# Altium schematic sheet PD2.SchDoc(PD2)
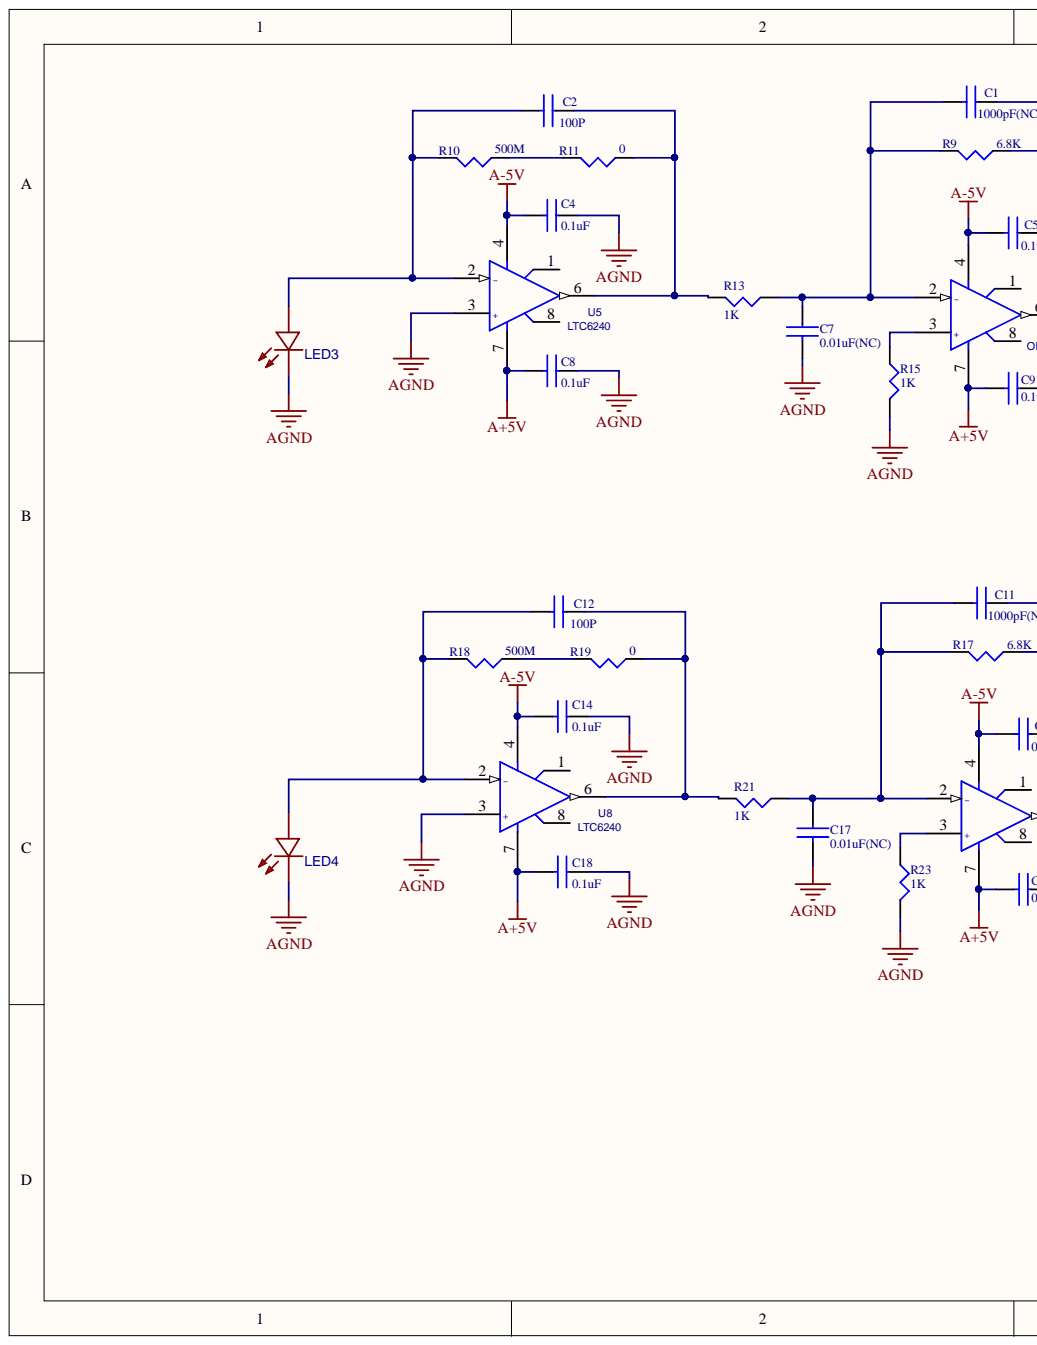
[diagram p0: PD2.SchDoc, left]
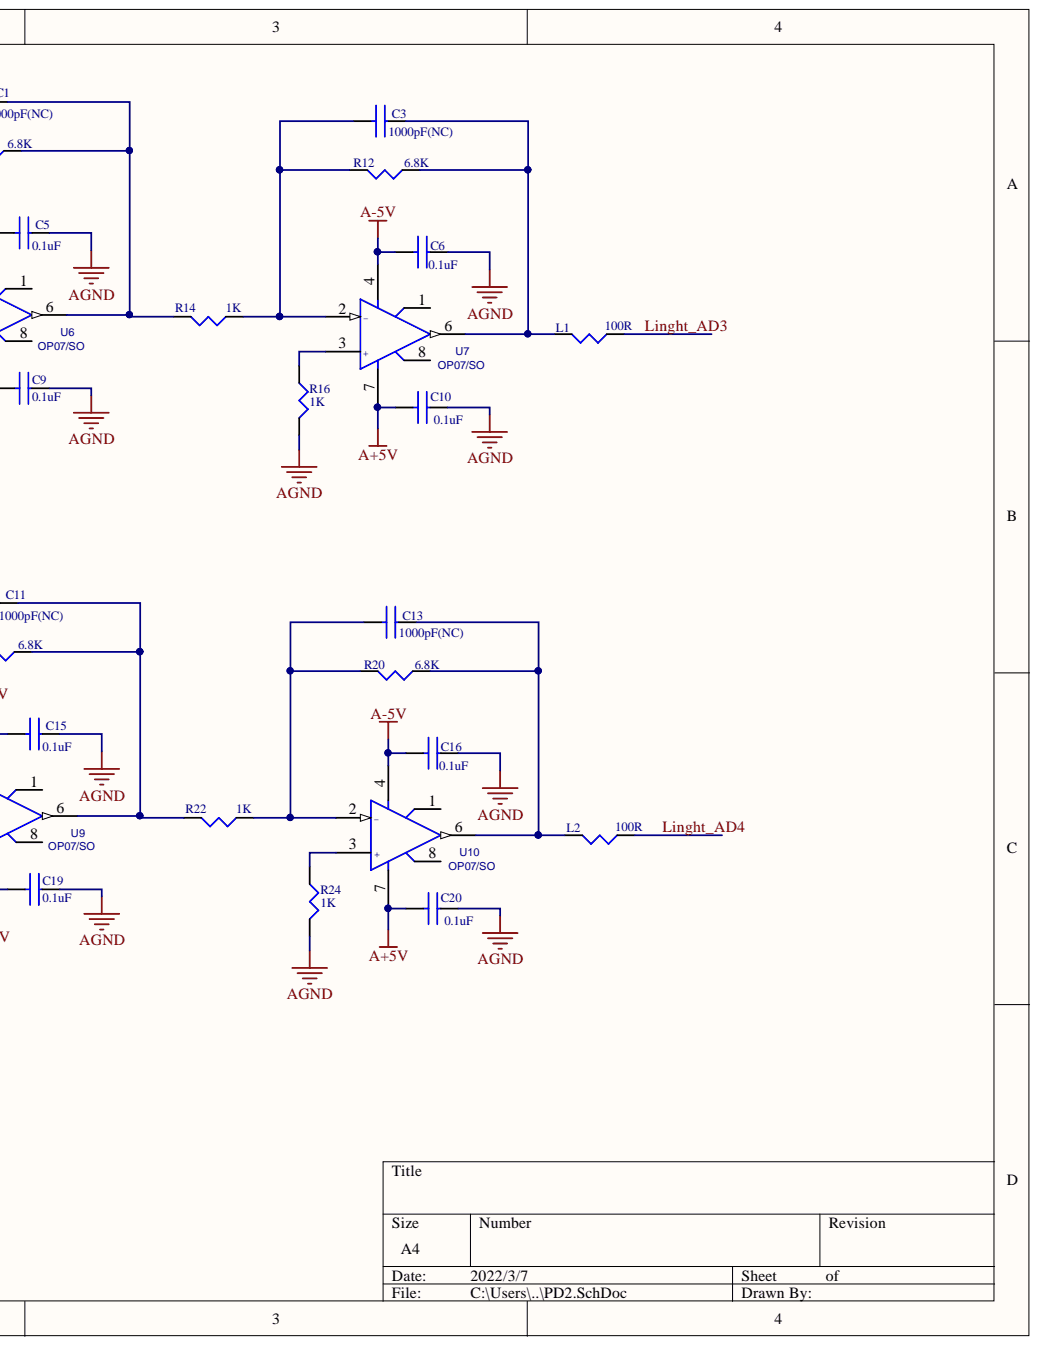
[diagram p1: PD2.SchDoc, right]

## Parts
C1  1000pF(NC)  [0603_C]
C2  100P  [0603_C]
C3  1000pF(NC)  [0603_C]
C4  0.1uF  [0603_C]
C5  0.1uF  [0603_C]
C6  0.1uF  [0603_C]
C7  0.01uF(NC)  [0603_C]
C8  0.1uF  [0603_C]
C9  0.1uF  [0603_C]
C10  0.1uF  [0603_C]
C11  1000pF(NC)  [0603_C]
C12  100P  [0603_C]
C13  1000pF(NC)  [0603_C]
C14  0.1uF  [0603_C]
C15  0.1uF  [0603_C]
C16  0.1uF  [0603_C]
C17  0.01uF(NC)  [0603_C]
C18  0.1uF  [0603_C]
C19  0.1uF  [0603_C]
C20  0.1uF  [0603_C]
L1  100R  [R0603]
L2  100R  [R0603]
LED3  LTST-C191TGKT  [PD]  (value not drawn)
LED4  LTST-C191TGKT  [PD]  (value not drawn)
R9  6.8K  [0603_R]
R10  500M  [0603_R]
R11  0  [0603_R]
R12  6.8K  [0603_R]
R13  1K  [0603_R]
R14  1K  [0603_R]
R15  1K  [0603_R]
R16  1K  [0603_R]
R17  6.8K  [0603_R]
R18  500M  [0603_R]
R19  0  [0603_R]
R20  6.8K  [0603_R]
R21  1K  [0603_R]
R22  1K  [0603_R]
R23  1K  [0603_R]
R24  1K  [0603_R]
U5  LTC6240  [TSOT-23-5]
U6  OP07/SO  [TSOT-23-5]
U7  OP07/SO  [TSOT-23-5]
U8  LTC6240  [TSOT-23-5]
U9  OP07/SO  [TSOT-23-5]
U10  OP07/SO  [TSOT-23-5]

## Nets
A+5V: C8.2 C9.2 C10.2 C18.2 C19.2 C20.2 U5.7 U6.7 U7.7 U8.7 U9.7 U10.7
A-5V: C4.2 C5.2 C6.2 C14.2 C15.2 C16.2 U5.4 U6.4 U7.4 U8.4 U9.4 U10.4
AGND: C4.1 C5.1 C6.1 C7.2 C8.1 C9.1 C10.1 C14.1 C15.1 C16.1 C17.2 C18.1 C19.1 C20.1 LED3.1 LED4.1 R15.2 R16.2 R23.2 R24.2 U5.3(+) U8.3(+)
Linght_AD3: L1.1
Linght_AD4: L2.1
NetC1_1: C1.1 R9.1 R14.2 U6.6
NetC1_2: C1.2 C7.1 R9.2 R13.1 U6.2(-)
NetC2_1: C2.1 R11.1 R13.2 U5.6
NetC2_2: C2.2 LED3.2 R10.2 U5.2(-)
NetC3_1: C3.1 L1.2 R12.1 U7.6
NetC3_2: C3.2 R12.2 R14.1 U7.2(-)
NetC11_1: C11.1 R17.1 R22.2 U9.6
NetC11_2: C11.2 C17.1 R17.2 R21.1 U9.2(-)
NetC12_1: C12.1 R19.1 R21.2 U8.6
NetC12_2: C12.2 LED4.2 R18.2 U8.2(-)
NetC13_1: C13.1 L2.2 R20.1 U10.6
NetC13_2: C13.2 R20.2 R22.1 U10.2(-)
NetR10_1: R10.1 R11.2
NetR15_1: R15.1 U6.3(+)
NetR16_1: R16.1 U7.3(+)
NetR18_1: R18.1 R19.2
NetR23_1: R23.1 U9.3(+)
NetR24_1: R24.1 U10.3(+)

Not connected: U5 1 8; U6 1 8; U7 1 8; U8 1 8; U9 1 8; U10 1 8

## Library data of the parts
C1-C20 = CAP NP 0805: Component Kind: Standard; Library Name: C:\Users\Lenovo\Desktop\定量PCR\电路图\PD板\PD.PcbLib
L1 L2 R9-R24 = RES 0805: Component Kind: Standard; Library Name: C-LifeReal_1.SchLib
LED3 LED4 = LTST-C191TGKT: BOM_Manufacturer: LITEON; Contributor: LCSC; spiceSymbolName: LTST-C191TGKT; spicePre: L; Component Kind: Standard; BOM_Supplier Part: C125101; BOM_Supplier: LCSC; BOM_Manufacturer Part: LTST-C191TGKT
U5-U10 = OP07/SO_1: Component Kind: Standard; Library Name: C-LifeReal_1.SchLib
